# Altium schematic sheet BTT_M157_MotorDrive_V0.3.SchDoc(BTT_M157_MotorDrive_V0.3)
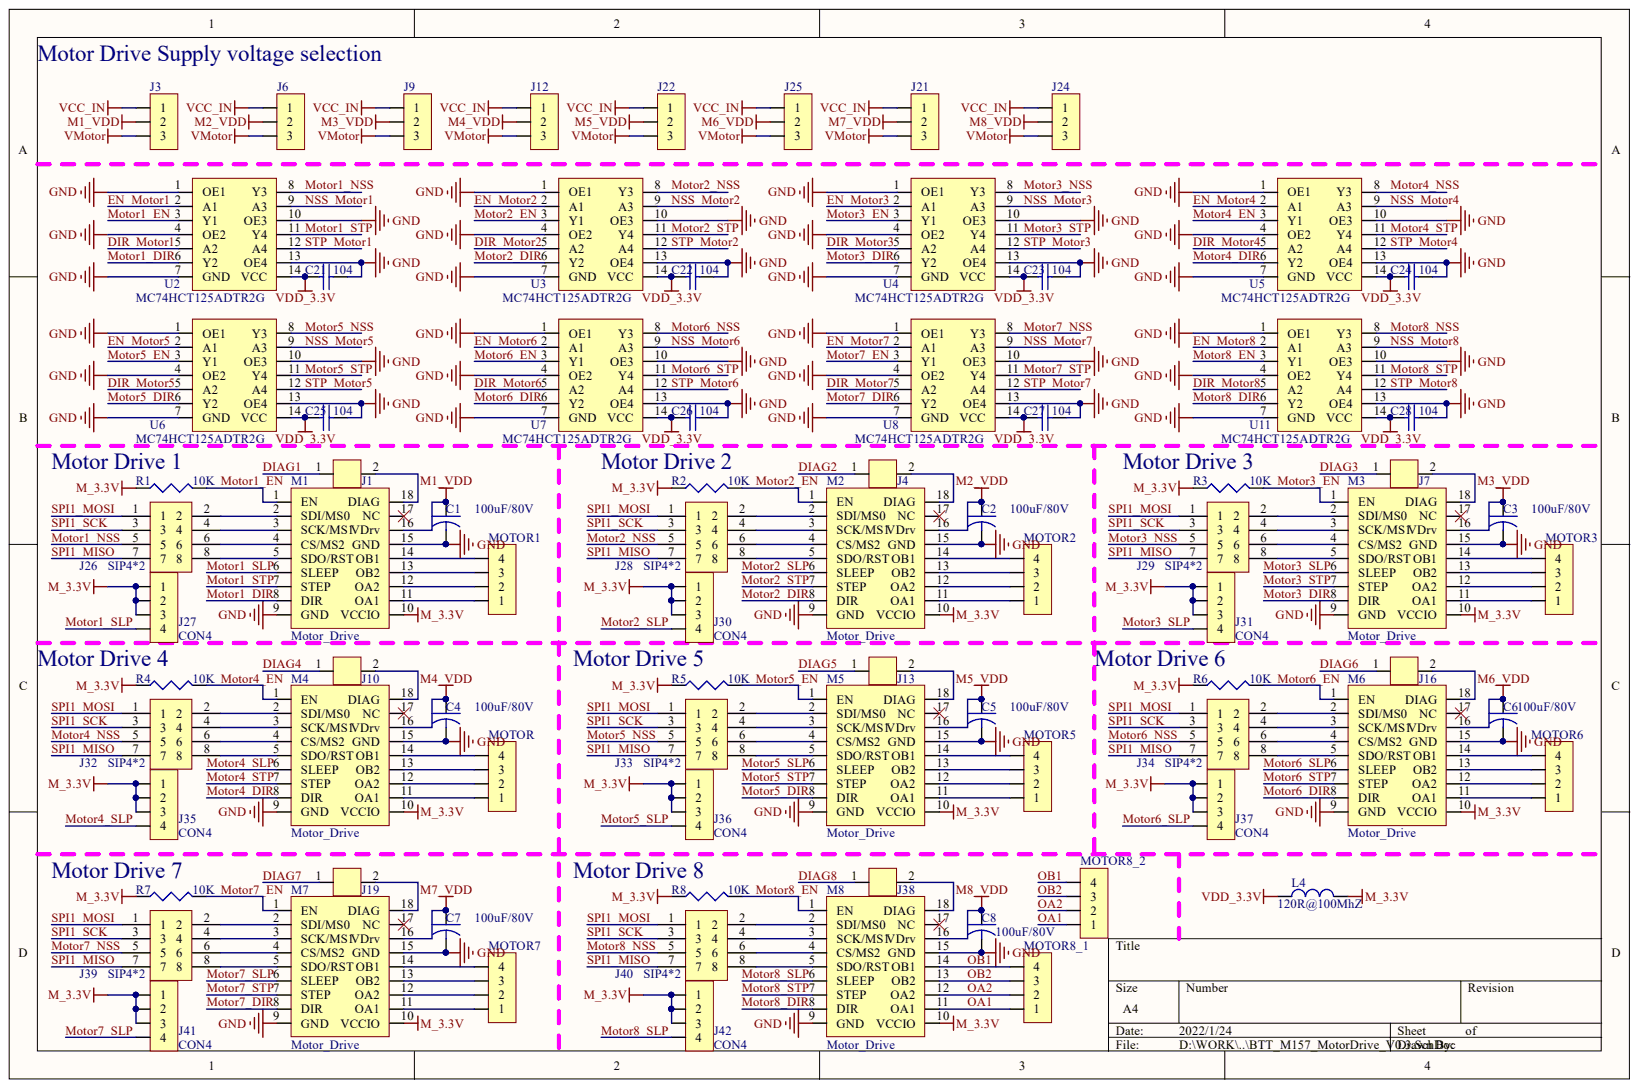
[diagram p0: BTT_M157_MotorDrive_V0.3.SchDoc]

## Parts
C1  100uF/80V  [CAP 5.0*10*9]
C2  100uF/80V  [CAP 5.0*10*9]
C3  100uF/80V  [CAP 5.0*10*9]
C4  100uF/80V  [CAP 5.0*10*9]
C5  100uF/80V  [CAP 5.0*10*9]
C6  100uF/80V  [CAP 5.0*10*9]
C7  100uF/80V  [CAP 5.0*10*9]
C8  100uF/80V  [CAP 5.0*10*9]
C21  104  [0603C]
C22  104  [0603C]
C23  104  [0603C]
C24  104  [0603C]
C25  104  [0603C]
C26  104  [0603C]
C27  104  [0603C]
C28  104  [0603C]
J1  Header 2_1  [SIP2-2.54-BLACK]  (value not drawn)
J3  CON3  [SIP3-2.54-BLACK]  (value not drawn)
J4  Header 2_1  [SIP2-2.54-BLACK]  (value not drawn)
J6  CON3  [SIP3-2.54-BLACK]  (value not drawn)
J7  Header 2_1  [SIP2-2.54-BLACK]  (value not drawn)
J9  CON3  [SIP3-2.54-BLACK]  (value not drawn)
J10  Header 2_1  [SIP2-2.54-BLACK]  (value not drawn)
J12  CON3  [SIP3-2.54-BLACK]  (value not drawn)
J13  Header 2_1  [SIP2-2.54-BLACK]  (value not drawn)
J16  Header 2_1  [SIP2-2.54-BLACK]  (value not drawn)
J19  Header 2_1  [SIP2-2.54-BLACK]  (value not drawn)
J21  CON3  [SIP3-2.54-BLACK]  (value not drawn)
J22  CON3  [SIP3-2.54-BLACK]  (value not drawn)
J24  CON3  [SIP3-2.54-BLACK]  (value not drawn)
J25  CON3  [SIP3-2.54-BLACK]  (value not drawn)
J26  SIP4*2  [SIP4*2-2.54-BIACK]
J27  CON4  [SIP4-2.54-BLACK]
J28  SIP4*2  [SIP4*2-2.54-BIACK]
J29  SIP4*2  [SIP4*2-2.54-BIACK]
J30  CON4  [SIP4-2.54-BLACK]
J31  CON4  [SIP4-2.54-BLACK]
J32  SIP4*2  [SIP4*2-2.54-BIACK]
J33  SIP4*2  [SIP4*2-2.54-BIACK]
J34  SIP4*2  [SIP4*2-2.54-BIACK]
J35  CON4  [SIP4-2.54-BLACK]
J36  CON4  [SIP4-2.54-BLACK]
J37  CON4  [SIP4-2.54-BLACK]
J38  Header 2_1  [SIP2-2.54-BLACK]  (value not drawn)
J39  SIP4*2  [SIP4*2-2.54-BIACK]
J40  SIP4*2  [SIP4*2-2.54-BIACK]
J41  CON4  [SIP4-2.54-BLACK]
J42  CON4  [SIP4-2.54-BLACK]
L4  120R@100MhZ  [0805L]
M1  Motor_Drive  [Motor_Drive]
M2  Motor_Drive  [Motor_Drive]
M3  Motor_Drive  [Motor_Drive]
M4  Motor_Drive  [Motor_Drive]
M5  Motor_Drive  [Motor_Drive]
M6  Motor_Drive  [Motor_Drive]
M7  Motor_Drive  [Motor_Drive]
M8  Motor_Drive  [Motor_Drive]
MOTOR  2.54-4P-直针插座  [2.54-4P-直针插座]  (value not drawn)
MOTOR1  2.54-4P-直针插座  [2.54-4P-直针插座]  (value not drawn)
MOTOR2  2.54-4P-直针插座  [2.54-4P-直针插座]  (value not drawn)
MOTOR3  2.54-4P-直针插座  [2.54-4P-直针插座]  (value not drawn)
MOTOR5  2.54-4P-直针插座  [2.54-4P-直针插座]  (value not drawn)
MOTOR6  2.54-4P-直针插座  [2.54-4P-直针插座]  (value not drawn)
MOTOR7  2.54-4P-直针插座  [2.54-4P-直针插座]  (value not drawn)
MOTOR8_1  2.54-4P-直针插座  [2.54-4P-直针插座]  (value not drawn)
MOTOR8_2  2.54-4P-直针插座  [2.54-4P-直针插座]  (value not drawn)
R1  10K  [0603R]
R2  10K  [0603R]
R3  10K  [0603R]
R4  10K  [0603R]
R5  10K  [0603R]
R6  10K  [0603R]
R7  10K  [0603R]
R8  10K  [0603R]
U2  MC74HCT125ADTR2G  [TSSOP14]
U3  MC74HCT125ADTR2G  [TSSOP14]
U4  MC74HCT125ADTR2G  [TSSOP14]
U5  MC74HCT125ADTR2G  [TSSOP14]
U6  MC74HCT125ADTR2G  [TSSOP14]
U7  MC74HCT125ADTR2G  [TSSOP14]
U8  MC74HCT125ADTR2G  [TSSOP14]
U11  MC74HCT125ADTR2G  [TSSOP14]

## Nets
GND: C1.2 C2.2 C3.2 C4.2 C5.2 C6.2 C7.2 C8.2 C21.2 C22.2 C23.2 C24.2 C25.2 C26.2 C27.2 C28.2 M1.9(GND) M1.15(GND) M2.9(GND) M2.15(GND) M3.9(GND) M3.15(GND) M4.9(GND) M4.15(GND) M5.9(GND) M5.15(GND) M6.9(GND) M6.15(GND) M7.9(GND) M7.15(GND) M8.9(GND) M8.15(GND) U2.1(OE1) U2.4(OE2) U2.7(GND) U2.10(OE3) U2.13(OE4) U3.1(OE1) U3.4(OE2) U3.7(GND) U3.10(OE3) U3.13(OE4) U4.1(OE1) U4.4(OE2) U4.7(GND) U4.10(OE3) U4.13(OE4) U5.1(OE1) U5.4(OE2) U5.7(GND) U5.10(OE3) U5.13(OE4) U6.1(OE1) U6.4(OE2) U6.7(GND) U6.10(OE3) U6.13(OE4) U7.1(OE1) U7.4(OE2) U7.7(GND) U7.10(OE3) U7.13(OE4) U8.1(OE1) U8.4(OE2) U8.7(GND) U8.10(OE3) U8.13(OE4) U11.1(OE1) U11.4(OE2) U11.7(GND) U11.10(OE3) U11.13(OE4)
M1_VDD: C1.1 J3.2 M1.16(VDrv)
M2_VDD: C2.1 J6.2 M2.16(VDrv)
M3_VDD: C3.1 J9.2 M3.16(VDrv)
M4_VDD: C4.1 J12.2 M4.16(VDrv)
M5_VDD: C5.1 J22.2 M5.16(VDrv)
M6_VDD: C6.1 J25.2 M6.16(VDrv)
M7_VDD: C7.1 J21.2 M7.16(VDrv)
M8_VDD: C8.1 J24.2 M8.16(VDrv)
M_3.3V: J27.1 J27.2 J27.3 J30.1 J30.2 J30.3 J31.1 J31.2 J31.3 J35.1 J35.2 J35.3 J36.1 J36.2 J36.3 J37.1 J37.2 J37.3 J41.1 J41.2 J41.3 J42.1 J42.2 J42.3 L4.2 M1.10(VCCIO) M2.10(VCCIO) M3.10(VCCIO) M4.10(VCCIO) M5.10(VCCIO) M6.10(VCCIO) M7.10(VCCIO) M8.10(VCCIO) R1.1 R2.1 R3.1 R4.1 R5.1 R6.1 R7.1 R8.1
VCC_IN: J3.1 J6.1 J9.1 J12.1 J21.1 J22.1 J24.1 J25.1
VDD_3.3V: C21.1 C22.1 C23.1 C24.1 C25.1 C26.1 C27.1 C28.1 L4.1 U2.14(VCC) U3.14(VCC) U4.14(VCC) U5.14(VCC) U6.14(VCC) U7.14(VCC) U8.14(VCC) U11.14(VCC)
VMOTOR: J3.3 J6.3 J9.3 J12.3 J21.3 J22.3 J24.3 J25.3
DIAG1: J1.1
DIAG2: J4.1
DIAG3: J7.1
DIAG4: J10.1
DIAG5: J13.1
DIAG6: J16.1
DIAG7: J19.1
DIAG8: J38.1
DIR_MOTOR1: U2.5(A2)
DIR_MOTOR2: U3.5(A2)
DIR_MOTOR3: U4.5(A2)
DIR_MOTOR4: U5.5(A2)
DIR_MOTOR5: U6.5(A2)
DIR_MOTOR6: U7.5(A2)
DIR_MOTOR7: U8.5(A2)
DIR_MOTOR8: U11.5(A2)
EN_MOTOR1: U2.2(A1)
EN_MOTOR2: U3.2(A1)
EN_MOTOR3: U4.2(A1)
EN_MOTOR4: U5.2(A1)
EN_MOTOR5: U6.2(A1)
EN_MOTOR6: U7.2(A1)
EN_MOTOR7: U8.2(A1)
EN_MOTOR8: U11.2(A1)
MOTOR1_DIR: M1.8(DIR) U2.6(Y2)
MOTOR1_EN: M1.1(EN) R1.2 U2.3(Y1)
MOTOR1_NSS: J26.5 U2.8(Y3)
MOTOR1_SLP: J27.4 M1.6(SLEEP)
MOTOR1_STP: M1.7(STEP) U2.11(Y4)
MOTOR2_DIR: M2.8(DIR) U3.6(Y2)
MOTOR2_EN: M2.1(EN) R2.2 U3.3(Y1)
MOTOR2_NSS: J28.5 U3.8(Y3)
MOTOR2_SLP: J30.4 M2.6(SLEEP)
MOTOR2_STP: M2.7(STEP) U3.11(Y4)
MOTOR3_DIR: M3.8(DIR) U4.6(Y2)
MOTOR3_EN: M3.1(EN) R3.2 U4.3(Y1)
MOTOR3_NSS: J29.5 U4.8(Y3)
MOTOR3_SLP: J31.4 M3.6(SLEEP)
MOTOR3_STP: M3.7(STEP) U4.11(Y4)
MOTOR4_DIR: M4.8(DIR) U5.6(Y2)
MOTOR4_EN: M4.1(EN) R4.2 U5.3(Y1)
MOTOR4_NSS: J32.5 U5.8(Y3)
MOTOR4_SLP: J35.4 M4.6(SLEEP)
MOTOR4_STP: M4.7(STEP) U5.11(Y4)
MOTOR5_DIR: M5.8(DIR) U6.6(Y2)
MOTOR5_EN: M5.1(EN) R5.2 U6.3(Y1)
MOTOR5_NSS: J33.5 U6.8(Y3)
MOTOR5_SLP: J36.4 M5.6(SLEEP)
MOTOR5_STP: M5.7(STEP) U6.11(Y4)
MOTOR6_DIR: M6.8(DIR) U7.6(Y2)
MOTOR6_EN: M6.1(EN) R6.2 U7.3(Y1)
MOTOR6_NSS: J34.5 U7.8(Y3)
MOTOR6_SLP: J37.4 M6.6(SLEEP)
MOTOR6_STP: M6.7(STEP) U7.11(Y4)
MOTOR7_DIR: M7.8(DIR) U8.6(Y2)
MOTOR7_EN: M7.1(EN) R7.2 U8.3(Y1)
MOTOR7_NSS: J39.5 U8.8(Y3)
MOTOR7_SLP: J41.4 M7.6(SLEEP)
MOTOR7_STP: M7.7(STEP) U8.11(Y4)
MOTOR8_DIR: M8.8(DIR) U11.6(Y2)
MOTOR8_EN: M8.1(EN) R8.2 U11.3(Y1)
MOTOR8_NSS: J40.5 U11.8(Y3)
MOTOR8_SLP: J42.4 M8.6(SLEEP)
MOTOR8_STP: M8.7(STEP) U11.11(Y4)
NSS_MOTOR1: U2.9(A3)
NSS_MOTOR2: U3.9(A3)
NSS_MOTOR3: U4.9(A3)
NSS_MOTOR4: U5.9(A3)
NSS_MOTOR5: U6.9(A3)
NSS_MOTOR6: U7.9(A3)
NSS_MOTOR7: U8.9(A3)
NSS_MOTOR8: U11.9(A3)
OA1: M8.11(OA1) MOTOR8_1.1 MOTOR8_2.1
OA2: M8.12(OA2) MOTOR8_1.2 MOTOR8_2.2
OB1: M8.14(OB1) MOTOR8_1.4 MOTOR8_2.4
OB2: M8.13(OB2) MOTOR8_1.3 MOTOR8_2.3
SPI1_MISO: J26.7 J28.7 J29.7 J32.7 J33.7 J34.7 J39.7 J40.7
SPI1_MOSI: J26.1 J28.1 J29.1 J32.1 J33.1 J34.1 J39.1 J40.1
SPI1_SCK: J26.3 J28.3 J29.3 J32.3 J33.3 J34.3 J39.3 J40.3
STP_MOTOR1: U2.12(A4)
STP_MOTOR2: U3.12(A4)
STP_MOTOR3: U4.12(A4)
STP_MOTOR4: U5.12(A4)
STP_MOTOR5: U6.12(A4)
STP_MOTOR6: U7.12(A4)
STP_MOTOR7: U8.12(A4)
STP_MOTOR8: U11.12(A4)
NetJ1_2: J1.2 M1.18(DIAG)
NetJ4_2: J4.2 M2.18(DIAG)
NetJ7_2: J7.2 M3.18(DIAG)
NetJ10_2: J10.2 M4.18(DIAG)
NetJ13_2: J13.2 M5.18(DIAG)
NetJ16_2: J16.2 M6.18(DIAG)
NetJ19_2: J19.2 M7.18(DIAG)
NetJ26_2: J26.2 M1.2(SDI/MS0)
NetJ26_4: J26.4 M1.3(SCK/MS1)
NetJ26_6: J26.6 M1.4(CS/MS2)
NetJ26_8: J26.8 M1.5(SDO/RST)
NetJ28_2: J28.2 M2.2(SDI/MS0)
NetJ28_4: J28.4 M2.3(SCK/MS1)
NetJ28_6: J28.6 M2.4(CS/MS2)
NetJ28_8: J28.8 M2.5(SDO/RST)
NetJ29_2: J29.2 M3.2(SDI/MS0)
NetJ29_4: J29.4 M3.3(SCK/MS1)
NetJ29_6: J29.6 M3.4(CS/MS2)
NetJ29_8: J29.8 M3.5(SDO/RST)
NetJ32_2: J32.2 M4.2(SDI/MS0)
NetJ32_4: J32.4 M4.3(SCK/MS1)
NetJ32_6: J32.6 M4.4(CS/MS2)
NetJ32_8: J32.8 M4.5(SDO/RST)
NetJ33_2: J33.2 M5.2(SDI/MS0)
NetJ33_4: J33.4 M5.3(SCK/MS1)
NetJ33_6: J33.6 M5.4(CS/MS2)
NetJ33_8: J33.8 M5.5(SDO/RST)
NetJ34_2: J34.2 M6.2(SDI/MS0)
NetJ34_4: J34.4 M6.3(SCK/MS1)
NetJ34_6: J34.6 M6.4(CS/MS2)
NetJ34_8: J34.8 M6.5(SDO/RST)
NetJ38_2: J38.2 M8.18(DIAG)
NetJ39_2: J39.2 M7.2(SDI/MS0)
NetJ39_4: J39.4 M7.3(SCK/MS1)
NetJ39_6: J39.6 M7.4(CS/MS2)
NetJ39_8: J39.8 M7.5(SDO/RST)
NetJ40_2: J40.2 M8.2(SDI/MS0)
NetJ40_4: J40.4 M8.3(SCK/MS1)
NetJ40_6: J40.6 M8.4(CS/MS2)
NetJ40_8: J40.8 M8.5(SDO/RST)
NetM1_11: M1.11(OA1) MOTOR1.1
NetM1_12: M1.12(OA2) MOTOR1.2
NetM1_13: M1.13(OB2) MOTOR1.3
NetM1_14: M1.14(OB1) MOTOR1.4
NetM2_11: M2.11(OA1) MOTOR2.1
NetM2_12: M2.12(OA2) MOTOR2.2
NetM2_13: M2.13(OB2) MOTOR2.3
NetM2_14: M2.14(OB1) MOTOR2.4
NetM3_11: M3.11(OA1) MOTOR3.1
NetM3_12: M3.12(OA2) MOTOR3.2
NetM3_13: M3.13(OB2) MOTOR3.3
NetM3_14: M3.14(OB1) MOTOR3.4
NetM4_11: M4.11(OA1) MOTOR.1
NetM4_12: M4.12(OA2) MOTOR.2
NetM4_13: M4.13(OB2) MOTOR.3
NetM4_14: M4.14(OB1) MOTOR.4
NetM5_11: M5.11(OA1) MOTOR5.1
NetM5_12: M5.12(OA2) MOTOR5.2
NetM5_13: M5.13(OB2) MOTOR5.3
NetM5_14: M5.14(OB1) MOTOR5.4
NetM6_11: M6.11(OA1) MOTOR6.1
NetM6_12: M6.12(OA2) MOTOR6.2
NetM6_13: M6.13(OB2) MOTOR6.3
NetM6_14: M6.14(OB1) MOTOR6.4
NetM7_11: M7.11(OA1) MOTOR7.1
NetM7_12: M7.12(OA2) MOTOR7.2
NetM7_13: M7.13(OB2) MOTOR7.3
NetM7_14: M7.14(OB1) MOTOR7.4

Not connected: M1 17(NC); M2 17(NC); M3 17(NC); M4 17(NC); M5 17(NC); M6 17(NC); M7 17(NC); M8 17(NC)

## Library data of the parts
C1-C8 = CAPACITOR: CAP 5.0*10*9; Component Kind: Standard; Library Name: My Useful Discrete Devices.SchLib
C21-C28 = CAP: Capacitor; Component Kind: Standard; Library Name: My Useful Discrete Devices.SchLib
J1 J4 J7 J10 J13 J16 J19 J38 = Header 2_1: Header, 2-Pin; Component Kind: Standard; LatestRevisionDate: 17-Jul-2002; LatestRevisionNote: Re-released for DXP Platform.; Signal Integrity: Connector; Library Name: My Useful Discrete Devices.SchLib; Publisher: Altium Limited
J3 J6 J9 J12 J21 J22 J24 J25 = CON3: Connector; Component Kind: Standard; Library Name: My Useful Discrete Devices.SchLib
J26 J28 J29 J32-J34 J39 J40 = con2X4: Component Kind: Standard; Library Name: My Useful Discrete Devices.SchLib
J27 J30 J31 J35-J37 J41 J42 MOTOR MOTOR1-MOTOR3 MOTOR5-MOTOR7 MOTOR8_1 MOTOR8_2 = CON4: Connector; Component Kind: Standard; Library Name: My Useful Discrete Devices.SchLib
L4 = INDUCTOR: Component Kind: Standard; Library Name: My Useful Discrete Devices.SchLib
M1-M8 = Motor_Drive1: 2.54MM排针版电机驱动模块; Component Kind: Standard; Library Name: My Useful Discrete Devices.SchLib
R1-R8 = RES1: Component Kind: Standard; Library Name: My Useful Discrete Devices.SchLib
U2-U8 U11 = MC74HCT125ADTR2G: Component Kind: Standard; Description: MC74HCT125ADTR2G; Library Name: My Useful IC.SchLib
